# Altium schematic sheet eps_can_transceiver.SchDoc
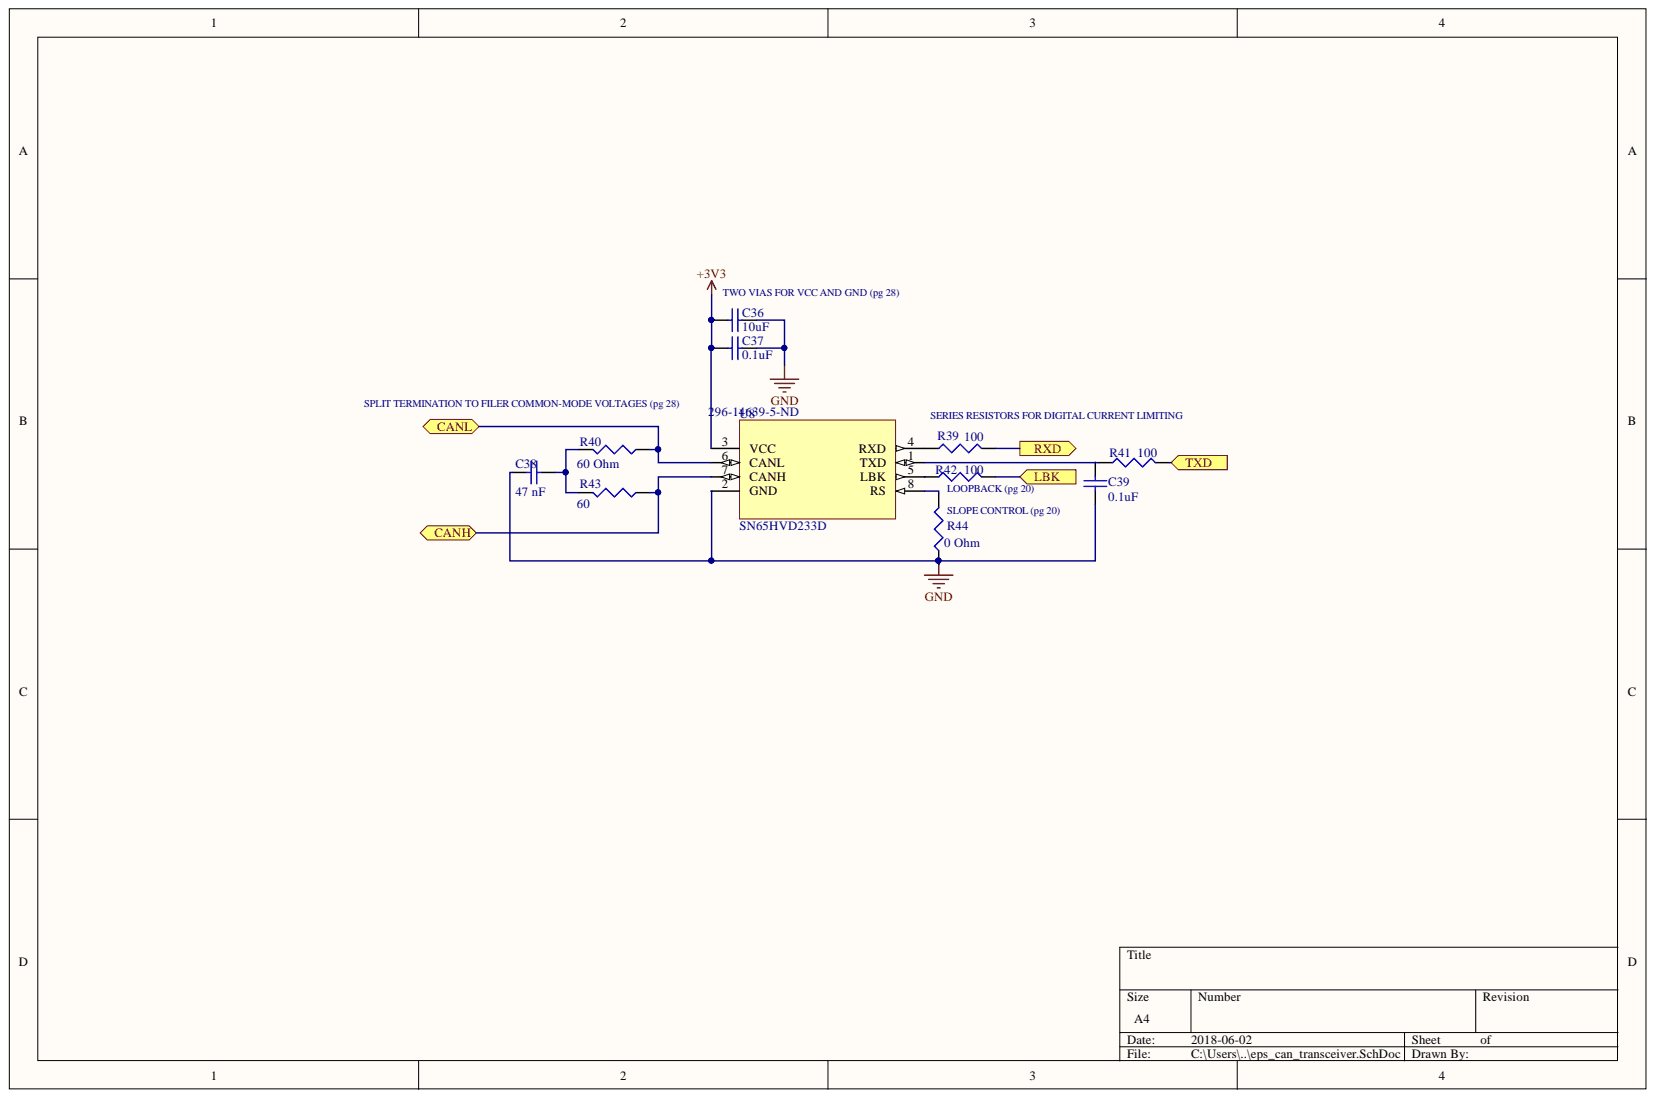
[diagram p0: eps_can_transceiver.SchDoc]

## Parts
C36  value 10uF  [1608[0603]]  (hidden comment: Cap Semi)
C37  value 0.1uF  [1608[0603]]  (hidden comment: Cap Semi)
C38  value 47 nF  [1608[0603]]  (hidden comment: Ceramic)
C39  0.1uF  [1608[0603]]
R39  value 100  [J1-0603]
R40  value 60 Ohm  [J1-0603]  (hidden comment: 0603)
R41  value 100  [J1-0603]
R42  100  [J1-0603]
R43  value 60  [J1-0603]  (hidden comment: 0603)
R44  value 0 Ohm  [J1-0603]
U8  SN65HVD233D  Supplier Part Number 1: 296-14639-5-ND  [SOIC127P500X600-8N]

## Ports
CANH, CANL, LBK, RXD, TXD

## Nets
+3V3: C36.2 C37.2 U8.3(VCC)
GND: C36.1 C37.1 C38.1 C39.1 R44.1 U8.2(GND)
CANH: R43.1 U8.7(CANH) ; port CANH
CANL: R40.1 U8.6(CANL) ; port CANL
LBK: R42.2 ; port LBK
RXD: R39.2 ; port RXD
TXD: R41.2 ; port TXD
NetC38_2: C38.2 R40.2 R43.2
NetR39_1: R39.1 U8.4(RXD)
NetR41_1: R41.1 U8.1(TXD)
NetR42_1: R42.1 U8.5(LBK)
NetR44_2: R44.2 U8.8(RS)

Not connected: C39 2

## Library data of the parts
C36-C39 = Cap Semi: Capacitor (Semiconductor SIM Model); Component Kind: Standard; LatestRevisionDate: 29-May-2009; LatestRevisionNote: IPC-7351 Footprint Added.; Library Name: Miscellaneous Devices.IntLib; PackageDescription: Chip Capacitor; PackageReference: C1206; Published: 8-Jun-2000; Signal Integrity: Cap Semi; Simulation: CAP; Publisher: Altium Limited
  C39: Value: 0.1uF
R39-R44 = Res3: Resistor; Component Kind: Standard; LatestRevisionDate: 29-May-2009; LatestRevisionNote: IPC-7351 Footprint Added.; Library Name: Miscellaneous Devices.IntLib; PackageDescription: Chip Resistor; PackageReference: J1-0603; Published: 8-Jun-2000; Signal Integrity: Res; Simulation: RESISTOR; Publisher: Altium Limited
  R42: Value: 100
U8 = SN65HVD233D: 3.3V CAN Transceiver with Standby Mode, Loop-back 8-SOIC -40 to 125; MF: Texas Instruments; Availability: Good; Component Kind: Standard; MP: SN65HVD233D; Package: SOIC-8 Texas Instruments; Price: 2.39 USD; SnapEDA_Link: https://www.snapeda.com/parts/SN65HVD233D/Texas%20Instruments/view-part/?ref=snap; Supplier 1: Digi-Key; Library Name: heron-general.IntLib
